# Altium schematic sheet Motorshield_ESP32_V4_schematic_outputjob.SchDoc (continued)

## Library data of the parts
A0-A3 = SOLDER_JUMPER_1206: Availability: Unavailable; Component Kind: Standard; MP: SOLDER_JUMPER_1206; Package: None; Price: None; Library Name: Motorshield_ESP32_V4_project_outputjob_1.SCHLIB
C1 = APD0609470M050R: Polymer Aluminium Capacitor Electrolyt; Manufacturer_JLCPCB: SHENGYANG; Manufacturer_Name: Kyocera AVX; Rotation Offset: 0; Component Kind: Standard; Datasheet Link: https://www.mouser.de/datasheet/2/40/kyocera_avx_apd_series-3177016.pdf; Footprint_JLCPCB: SMD,D6.3xL8mm; Height: 9.8mm; JLC PCB: C44606723; Manufacturer_Part_Number: APD0609470M050R; Library Name: Motorshield_ESP32_V4_project_outputjob_1.SCHLIB
C2 = APD0809101M050R: Polymer Aluminium Capacitor Electrolyt; Manufacturer_JLCPCB: Honor Elec; Manufacturer_Name: Kyocera AVX; Rotation Offset: 0; Component Kind: Standard; Datasheet Link: https://datasheets.kyocera-avx.com/kyocera-avx-apd-series.pdf; Footprint_JLCPCB: SMD,D8xL10.5mm; Height: 10mm; JLC PCB: C5246561; Manufacturer_Part_Number: APD0809101M050R; Mouser Part Number: 581-APD0809101M050R; Mouser Price/Stock: https://www.mouser.co.uk/ProductDetail/KYOCERA-AVX/APD0809101M050R?qs=Wj%2FVkw3K%252BMCgILDsoaMRTw%3D%3D; Library Name: Motorshield_ESP32_V4_project_outputjob_1.SCHLIB
C3 C5 C7 C8 C10 C11 C14 C16 C17 C19-C25 C32 C38 = 06035C104KAZ4A: Ceramic Capacitor MLCC; Manufacturer_JLCPCB: YAGEO; Manufacturer_Name: Kyocera AVX; Rotation Offset: 0; Arrow Part Number: 06035C104KAZ4A; Arrow Price/Stock: https://www.arrow.com/en/products/06035c104kaz4a/avx; Component Kind: Standard; Datasheet Link: https://componentsearchengine.com/Datasheets/1/06031A100FAT2A.pdf; Footprint_JLCPCB: 0603; Height: 0.9mm; JLC PCB: C14663; Manufacturer_Part_Number: 06035C104KAZ4A; Mouser Part Number: 581-06035C104KAZ4A; Mouser Price/Stock: https://www.mouser.co.uk/ProductDetail/AVX/06035C104KAZ4A/?qs=NI4NqA541gtiVdo7Hwl8%252BQ%3D%3D; Library Name: Motorshield_ESP32_V4_project_outputjob_1.SCHLIB
C4 C6 C12 C13 C37 = GRM21BZ71E106KE15L: Ceramitc Capacitor MLCC; Manufacturer_JLCPCB: Murata Electronics; Rotation Offset: 0; Arrow Part Number: GRM21BZ71E106KE15L; Arrow Price/Stock: https://www.arrow.com/en/products/grm21bz71e106ke15l/murata-manufacturing?utm_currency=USD&region=europe; Component Kind: Standard; Datasheet Link: https://search.murata.co.jp/Ceramy/image/img/A01X/G101/ENG/GRM21BZ71E106KE15-01A.pdf; Footprint_JLCPCB: 0805; Height: 1.45mm; JLC PCB: C440198; Manufacturer_Name: Murata Electronics; Manufacturer_Part_Number: GRM21BZ71E106KE15L; Mouser Part Number: 81-GRM21BZ71E106KE5L; Mouser Price/Stock: https://www.mouser.co.uk/ProductDetail/Murata-Electronics/GRM21BZ71E106KE15L?qs=Ey7%2FXF42M3fdXe9bIpCO9w%3D%3D; Library Name: Motorshield_ESP32_V4_project_outputjob_1.SCHLIB
C9 C15 C33-C36 = 06035C103K4T4A: Ceramic Capacitor MLCC; Manufacturer_JLCPCB: FH (Guangdong Fenghua Advanced Tech); Manufacturer_Name: Kyocera AVX; Rotation Offset: 0; Component Kind: Standard; Datasheet Link: https://componentsearchengine.com/Datasheets/1/06031A100FAT2A.pdf; Footprint_JLCPCB: 0603; Height: 0.9mm; JLC PCB: C57112; Manufacturer_Part_Number: 06035C103K4T4A; Mouser Part Number: 581-06035C103K4T4A; Mouser Price/Stock: https://www.mouser.com/Search/Refine.aspx?Keyword=581-06035C103K4T4A; Library Name: Motorshield_ESP32_V4_project_outputjob_1.SCHLIB
C18 = 06035C104KAZ4A: Ceramic Capacitor MLCC; Manufacturer_JLCPCB: YAGEO; Manufacturer_Name: Kyocera AVX; Arrow Part Number: 06035C104KAZ4A; Arrow Price/Stock: https://www.arrow.com/en/products/06035c104kaz4a/avx; Component Kind: Standard; Datasheet Link: https://componentsearchengine.com/Datasheets/1/06031A100FAT2A.pdf; Footprint_JLCPCB: 0603; Height: 0.9mm; JLC PCB: C14663; Manufacturer_Part_Number: 06035C104KAZ4A; Mouser Part Number: 581-06035C104KAZ4A; Mouser Price/Stock: https://www.mouser.co.uk/ProductDetail/AVX/06035C104KAZ4A/?qs=NI4NqA541gtiVdo7Hwl8%252BQ%3D%3D; Library Name: Motorshield_ESP32_V4_project_outputjob_1.SCHLIB
C26-C31 = EEH-ZC1H220P: Aluminium Capacitor Electrolyt; Manufacturer_JLCPCB: Honor Elec; Manufacturer_Name: Panasonic; Rotation Offset: +180; Component Kind: Standard; Datasheet Link: http://industrial.panasonic.com/cdbs/www-data/pdf/RDD0000/ABA0000C1234.pdf; Footprint_JLCPCB: SMD,D6.3xL5.8mm; Height: 8.3mm; JLC PCB: C5246560; Manufacturer_Part_Number: EEH-ZC1H220P; Mouser Part Number: 667-EEH-ZC1H220P; Mouser Price/Stock: https://www.mouser.co.uk/ProductDetail/Panasonic/EEH-ZC1H220P?qs=7UCyOFMWVHZ19OvZTDuZOA%3D%3D; Library Name: Motorshield_ESP32_V4_project_outputjob_1.SCHLIB
D1 = SBR3U40S1FQ-7: Diode, super barrier rectifiers; Manufacturer_JLCPCB: Diodes Incorporated; Rotation Offset: 0; Arrow Part Number: SBR3U40S1FQ-7; Arrow Price/Stock: https://www.arrow.com/en/products/sbr3u40s1fq-7/diodes-incorporated; Component Kind: Standard; Datasheet Link: https://www.diodes.com/assets/Datasheets/SBR3U40S1FQ.pdf; Footprint_JLCPCB: SOD-123F; Height: 1.15mm; JLC PCB: C4360673; Manufacturer_Name: Diodes Incorporated; Manufacturer_Part_Number: SBR3U40S1FQ-7; Mouser Part Number: 621-SBR3U40S1FQ-7; Mouser Price/Stock: https://www.mouser.co.uk/ProductDetail/Diodes-Incorporated/SBR3U40S1FQ-7?qs=1mbolxNpo8ch7miqGKbORA%3D%3D; Library Name: Motorshield_ESP32_V4_project_outputjob_1.SCHLIB
D2-D7 = CDSOD323-T15S: TVS Diode, unidirectional ESD/Surge Protection 15V; Manufacturer_JLCPCB: HXY MOSFET; Manufacturer_Name: Bourns; Rotation Offset: 0; Arrow Part Number: CDSOD323-T15S; Arrow Price/Stock: https://www.arrow.com/en/products/cdsod323-t15s/bourns?region=nac; Component Kind: Standard; Datasheet Link: https://www.bourns.com/docs/Product-Datasheets/CDSOD323-TxxSC.pdf; Footprint_JLCPCB: SOD-323; Height: 1.14mm; JLC PCB: C22439717; Manufacturer_Part_Number: CDSOD323-T15S; Mouser Part Number: 652-CDSOD323-T15S; Mouser Price/Stock: https://www.mouser.co.uk/ProductDetail/Bourns/CDSOD323-T15S?qs=6S5Watp5UnLXcGC4%252BIE2dA%3D%3D; Library Name: Motorshield_ESP32_V4_project_outputjob_1.SCHLIB
IC1 = PCA9617ADPJ: Integrated Circuit, level shifter I2C; Manufacturer_JLCPCB: NXP Semiconductor; Manufacturer_Name: NXP; Rotation Offset: +90; Arrow Part Number: PCA9617ADPJ; Arrow Price/Stock: https://www.arrow.com/en/products/pca9617adpj/nxp-semiconductors?utm_currency=USD&region=nac; Component Kind: Standard; Datasheet Link: http://www.nxp.com/docs/en/data-sheet/PCA9617A.pdf; Footprint_JLCPCB: TSSOP-8; Height: 1.1mm; JLC PCB: C128388; Manufacturer_Part_Number: PCA9617ADPJ; Mouser Part Number: 771-PCA9617ADPJ; Mouser Price/Stock: https://www.mouser.co.uk/ProductDetail/NXP-Semiconductors/PCA9617ADPJ?qs=cvU09TOkIMn2SIzokGN5qg%3D%3D; Library Name: Motorshield_ESP32_V4_project_outputjob_1.SCHLIB
IC2 IC6 = PCA9685PW,118: Integrated Circuit, LED Drivers/LED Drivers, I2C; Manufacturer_JLCPCB: NXP Semiconductor; Manufacturer_Name: NXP; Rotation Offset: 0; Arrow Part Number: PCA9685PW,118; Arrow Price/Stock: https://www.arrow.com/en/products/pca9685pw118/nxp-semiconductors?utm_currency=USD&region=nac; Component Kind: Standard; Datasheet Link: http://www.nxp.com/docs/en/data-sheet/PCA9685.pdf; Footprint_JLCPCB: TSSOP-28; Height: 1.1mm; JLC PCB: C2678753; Manufacturer_Part_Number: PCA9685PW,118; Mouser Part Number: 771-PCA9685PW,118; Mouser Price/Stock: https://www.mouser.co.uk/ProductDetail/NXP-Semiconductors/PCA9685PW118?qs=6YAT8rHPFU%252BtfwwKq4nwEQ%3D%3D; Library Name: Motorshield_ESP32_V4_project_outputjob_1.SCHLIB
IC3 IC4 = ADS1015IRUGR: Integrated Circuit, Data Acquisition/Analog to Digital Converters; Manufacturer_JLCPCB: Texas Instruments; Rotation Offset: 0; Arrow Part Number: ADS1015IRUGR; Arrow Price/Stock: https://www.arrow.com/en/products/ads1015irugr/texas-instruments?utm_currency=USD&region=nac; Component Kind: Standard; Datasheet Link: https://www.ti.com/lit/ds/symlink/ads1014.pdf?HQS=dis-mous-null-mousermode-dsf-pf-null-wwe&ts=1693594019978&ref_url=https%253A%252F%252Fwww.mouser.mx%252F; Footprint_JLCPCB: X2-QFN-10(1.5x2); Height: 0.4mm; JLC PCB: C468259; Manufacturer_Name: Texas Instruments; Manufacturer_Part_Number: ADS1015IRUGR; Mouser Part Number: 595-ADS1015IRUGR; Mouser Price/Stock: https://www.mouser.co.uk/ProductDetail/Texas-Instruments/ADS1015IRUGR?qs=IK5e5L0zOXiH4nzJ%2FqgaZA%3D%3D; Library Name: Motorshield_ESP32_V4_project_outputjob_1.SCHLIB
IC5 = MCP23017T-E_SS: Integrated Circuit, Interface I/O Expander 16 channel with interrupt; Manufacturer_JLCPCB: Microchip Tech; Manufacturer_Name: Microchip; Manufacturer_Part_Number: MCP23017T-E/SS; Rotation Offset: +90; Arrow Part Number: MCP23017T-E/SS; Arrow Price/Stock: https://www.arrow.com/en/products/mcp23017t-ess/microchip-technology?utm_currency=USD&region=nac; Component Kind: Standard; Datasheet Link: https://datasheet.datasheetarchive.com/originals/distributors/SFDatasheet-4/sf-00090057.pdf; Footprint_JLCPCB: SSOP-28; Height: 2mm; JLC PCB: C558584; Mouser Part Number: 579-MCP23017T-E/SS; Mouser Price/Stock: https://www.mouser.co.uk/ProductDetail/Microchip-Technology/MCP23017T-E-SS?qs=usxtMOJb1Rz2FhASIaFluQ%3D%3D; Library Name: Motorshield_ESP32_V4_project_outputjob_1.SCHLIB
J1 = Header links ESP32-S3: Connector, 22ckt, 1 row, pitch: 2.54mm, female; Manufacturer_JLCPCB: Megastar; Manufacturer_Name: SAMTEC; Manufacturer_Part_Number: CES-122-02-S-S; Rotation Offset: 0; Component Kind: Standard; Datasheet Link: https://suddendocs.samtec.com/prints/ces-1xx-xx-x-s-xx-mkt.pdf; Footprint_JLCPCB: Plugin, P = 2.54mm; Height: 5.207mm; JLC PCB: C7499337; Library Name: Motorshield_ESP32_V4_project_outputjob_1.SCHLIB
J2 = Header rechts ESP32-S3_1: Connector, 22ckt, 1 row, pitch: 2.54mm, female; Manufacturer_JLCPCB: Megastar; Manufacturer_Name: SAMTEC; Manufacturer_Part_Number: CES-122-02-S-S; Rotation Offset: 0; Component Kind: Standard; Datasheet Link: https://suddendocs.samtec.com/prints/ces-1xx-xx-x-s-xx-mkt.pdf; Footprint_JLCPCB: Plugin, P = 2.54mm; Height: 5.207mm; JLC PCB: C7499337; Library Name: Motorshield_ESP32_V4_project_outputjob_1.SCHLIB
J3 J4 J6-J8 = 90147-1108: Connector, 8ckt, 1 row, pitch: 2.54mm, female; Manufacturer_JLCPCB: BOOMELE(Boom Precision Elec); Manufacturer_Name: Molex; Rotation Offset: 0; Component Kind: Standard; Datasheet Link: https://www.molex.com/pdm_docs/sd/901471102_sd.pdf; Footprint_JLCPCB: Plugin, P = 2.54mm; Height: 8.7mm; JLC PCB: C27438; Manufacturer_Part_Number: 90147-1108; Mouser Part Number: 538-90147-1108; Mouser Price/Stock: https://www.mouser.co.uk/ProductDetail/Molex/90147-1108?qs=QESXi79X%252BKqiwXr7kUdEeg%3D%3D; Library Name: Motorshield_ESP32_V4_project_outputjob_1.SCHLIB
J5 = 691101710002: Connector, 2 ckt, screw terminal, picht: 5mm, 12A, blue; Manufacturer_JLCPCB: DORABO; Manufacturer_Name: Wurth Elektronik; Rotation Offset: +180; Component Kind: Standard; Datasheet Link: https://www.we-online.com/catalog/datasheet/691101710002.pdf; Footprint_JLCPCB: Plugin, P = 2.54mm; Height: 12.6mm; JLC PCB: C500019; Manufacturer_Part_Number: 691101710002; Mouser Part Number: 710-691101710002; Mouser Price/Stock: https://www.mouser.co.uk/ProductDetail/Wurth-Elektronik/691101710002?qs=7gQLVZk5cPko2q9q3mYFyQ%3D%3D; Library Name: Motorshield_ESP32_V4_project_outputjob_1.SCHLIB
J9 = 61300811121: Connector, 8ckt, 1 row, pitch: 2.54mm, male, yellow; Manufacturer_JLCPCB: XFCN; Manufacturer_Name: Wurth Elektronik; Rotation Offset: 0; Component Kind: Standard; Datasheet Link: https://www.we-online.com/components/products/download/3D_61300811121+%28rev1%29.pdf; Footprint_JLCPCB: Plugin, P = 2.54mm; Height: 8.54mm; JLC PCB: C41425311; Manufacturer_Part_Number: 61300811121; Mouser Part Number: 710-61300811121; Mouser Price/Stock: https://www.mouser.co.uk/ProductDetail/Wurth-Elektronik/61300811121?qs=PhR8RmCirEZRdx%252BVlEmRRA%3D%3D; Library Name: Motorshield_ESP32_V4_project_outputjob_1.SCHLIB
J10 = 61300811121: Connector, 8ckt, 1 row, pitch: 2.54mm, male, red; Manufacturer_JLCPCB: XFCN; Manufacturer_Name: Wurth Elektronik; Rotation Offset: 0; Component Kind: Standard; Datasheet Link: https://www.we-online.com/components/products/download/3D_61300811121+%28rev1%29.pdf; Footprint_JLCPCB: Plugin, P = 2.54mm; Height: 8.54mm; JLC PCB: C41425298; Manufacturer_Part_Number: 61300811121; Mouser Part Number: 710-61300811121; Mouser Price/Stock: https://www.mouser.co.uk/ProductDetail/Wurth-Elektronik/61300811121?qs=PhR8RmCirEZRdx%252BVlEmRRA%3D%3D; Library Name: Motorshield_ESP32_V4_project_outputjob_1.SCHLIB
J11 = 61300811121: Connector, 8ckt, 1 row, pitch: 2.54mm, male, black; Manufacturer_JLCPCB: XFCN; Manufacturer_Name: Wurth Elektronik; Rotation Offset: 0; Component Kind: Standard; Datasheet Link: https://www.we-online.com/components/products/download/3D_61300811121+%28rev1%29.pdf; Footprint_JLCPCB: Plugin, P = 2.54mm; Height: 8.54mm; JLC PCB: C492407; Manufacturer_Part_Number: 61300811121; Mouser Part Number: 710-61300811121; Mouser Price/Stock: https://www.mouser.co.uk/ProductDetail/Wurth-Elektronik/61300811121?qs=PhR8RmCirEZRdx%252BVlEmRRA%3D%3D; Library Name: Motorshield_ESP32_V4_project_outputjob_1.SCHLIB
J13 J15 J17 J18 = 5442170: Connector, 2 ckt, screw terminal, picht: 5mm, 12A, green; Manufacturer_JLCPCB: DORABO; Manufacturer_Name: Phoenix Contact; Rotation Offset: 0; Arrow Part Number: 5442170; Arrow Price/Stock: https://www.arrow.com/en/products/5442170/phoenix-contact; Component Kind: Standard; Datasheet Link: https://www.phoenixcontact.com/en-us/products/printed-circuit-board-terminal-bc-500x10-2-gn-5442170?type=pdf; Footprint_JLCPCB: Plugin, P = 5mm; Height: 10.12mm; JLC PCB: C395885; Manufacturer_Part_Number: 5442170; Mouser Part Number: 651-5442170; Mouser Price/Stock: https://www.mouser.co.uk/ProductDetail/Phoenix-Contact/5442170?qs=9Y9qB%2FCsC3l20JbvAQBiIQ%3D%3D; Library Name: Motorshield_ESP32_V4_project_outputjob_1.SCHLIB
J14 J16 = 5441537: Connector, 2 ckt, screw terminal, picht: 5mm, 12A, black; Manufacturer_JLCPCB: DORABO; Manufacturer_Name: Phoenix Contact; Rotation Offset: 0; Component Kind: Standard; Datasheet Link: https://www.phoenixcontact.com/en-us/products/printed-circuit-board-terminal-bc-500x10-2-bk-5441537?type=pdf; Footprint_JLCPCB: Plugin, P = 5mm; Height: 10.12mm; JLC PCB: C430623; Manufacturer_Part_Number: 5441537; Mouser Part Number: 651-5441537; Mouser Price/Stock: https://www.mouser.co.uk/ProductDetail/Phoenix-Contact/5441537?qs=iCzJi%2FIZBF68%252B9JNIUQa4w%3D%3D; Library Name: Motorshield_ESP32_V4_project_outputjob_1.SCHLIB
J19 = 90147-1107: Connector, 7 ckt, 1 row, pitch: 2.54mm, female; Manufacturer_JLCPCB: XFCN; Manufacturer_Name: Molex; Rotation Offset: 0; Component Kind: Standard; Datasheet Link: https://componentsearchengine.com/Datasheets/2/90147-1107.pdf; Footprint_JLCPCB: Plugin, P = 2.54mm; Height: 11.8mm; JLC PCB: C2832270; Manufacturer_Part_Number: 90147-1107; Mouser Part Number: 538-90147-1107; Mouser Price/Stock: https://www.mouser.co.uk/ProductDetail/Molex/90147-1107?qs=QESXi79X%252BKpbYN2OdNw17A%3D%3D; Library Name: Motorshield_ESP32_V4_project_outputjob_1.SCHLIB
J25 = SM04B-SRSS-TB_LF__SN_: Connector, QWIIC, wire to board; Manufacturer_JLCPCB: JST; Manufacturer_Name: JST (JAPAN SOLDERLESS TERMINALS); Manufacturer_Part_Number: SM04B-SRSS-TB(LF)(SN); Rotation Offset: 0; Arrow Part Number: SM04B-SRSS-TB(LF)(SN); Arrow Price/Stock: https://www.arrow.com/en/products/sm04b-srss-tb-lf-sn/jst-manufacturing.html?utm_currency=USD&region=europe; Component Kind: Standard; Datasheet Link: http://www.jst-mfg.com/product/pdf/eng/eSH.pdf; Footprint_JLCPCB: SMD,P=1mm,Surface Mount, right Angle; JLC PCB: C160404; Mouser Part Number: 306-SM04BSRSSTBLFSN; Mouser Price/Stock: https://www.mouser.co.uk/ProductDetail/JST-Commercial/SM04B-SRSS-TBLFSN?qs=cdbOS8ANM9B3FdyA6cNU2A%3D%3D; Library Name: Motorshield_ESP32_V4_project_outputjob_1.SCHLIB
Q1 = DMP3020LSS-13: P-MOSFET,  1 channel; Manufacturer_JLCPCB: Diodes Incorporated; Rotation Offset: +90; Component Kind: Standard; Datasheet Link: https://www.diodes.com//assets/Datasheets/ds31263.pdf; Footprint_JLCPCB: SO-8; Height: 1.75mm; JLC PCB: C155319; Manufacturer_Name: Diodes Incorporated; Manufacturer_Part_Number: DMP3020LSS-13; Mouser Part Number: 621-DMP3020LSS-13; Mouser Price/Stock: https://www.mouser.co.uk/ProductDetail/Diodes-Incorporated/DMP3020LSS-13?qs=ptj1V1atRApSH7nA47yoew%3D%3D; Library Name: Motorshield_ESP32_V4_project_outputjob_1.SCHLIB
R1 R3 R6 R14 R16 R17 R44-R47 = MCT0603MD1002DP500: Resistor  1%; Manufacturer_JLCPCB: YAGEO; Manufacturer_Name: Vishay; Rotation Offset: 0; Arrow Part Number: MCT0603MD1002DP500; Arrow Price/Stock: https://www.arrow.com/en/products/mct0603md1002dp500/vishay?region=nac; Component Kind: Standard; Datasheet Link: http://www.vishay.com/docs/28705/mcx0x0xpro.pdf; Footprint_JLCPCB: 0603; Height: 0.55mm; JLC PCB: C25804; Manufacturer_Part_Number: MCT0603MD1002DP500; Mouser Part Number: 594-MCT0603MD1002DP5; Mouser Price/Stock: https://www.mouser.co.uk/ProductDetail/Vishay-Beyschlag/MCT0603MD1002DP500?qs=oBjDkOCjPF1CUAoPD7yTwg%3D%3D; Library Name: Motorshield_ESP32_V4_project_outputjob_1.SCHLIB
R2 = RC0603FR-104K7L: Resistor  1%; Manufacturer_JLCPCB: UNI-ROYAL(Uniroyal Elec); Manufacturer_Name: YAGEO; Rotation Offset: 0; Arrow Part Number: RC0603FR-104K7L; Arrow Price/Stock: https://www.arrow.com/en/products/rc0603fr-104k7l/yageo; Component Kind: Standard; Datasheet Link: http://www.yageo.com/documents/recent/PYu-RC0603_51_RoHS_L_v5.pdf; Footprint_JLCPCB: 0603; Height: 0.55mm; JLC PCB: C23162; Manufacturer_Part_Number: RC0603FR-104K7L; Mouser Part Number: 603-RC0603FR-104K7L; Mouser Price/Stock: https://www.mouser.co.uk/ProductDetail/Yageo/RC0603FR-104K7L?qs=EiqXWrxQq63AD8HOZpfnFQ%3D%3D; Library Name: Motorshield_ESP32_V4_project_outputjob_1.SCHLIB
R4 = MCT06030C2701FP500: Resistor  1%; Manufacturer_JLCPCB: UNI-ROYAL(Uniroyal Elec); Manufacturer_Name: Vishay; Rotation Offset: 0; Component Kind: Standard; Datasheet Link: http://www.vishay.com/docs/28705/mcx0x0xpro.pdf; Footprint_JLCPCB: 0603; Height: 0.55mm; JLC PCB: C13167; Manufacturer_Part_Number: MCT06030C2701FP500; Mouser Part Number: 594-MCT06030C2701FP5; Mouser Price/Stock: https://www.mouser.co.uk/ProductDetail/Vishay-Beyschlag/MCT06030C2701FP500?qs=x3mz4GabKuo1b7QaS88WTg%3D%3D; Library Name: Motorshield_ESP32_V4_project_outputjob_1.SCHLIB
R5 = RC0603FR-136K8L: Resistor  1%; Manufacturer_JLCPCB: UNI-ROYAL(Uniroyal Elec); Manufacturer_Name: YAGEO; Rotation Offset: 0; Component Kind: Standard; Datasheet Link: http://www.yageo.com/documents/recent/PYu-RC0603_51_RoHS_L_v5.pdf; Footprint_JLCPCB: 0603; Height: 0.55mm; JLC PCB: C23212; Manufacturer_Part_Number: RC0603FR-136K8L; Mouser Part Number: 603-RC0603FR-136K8L; Mouser Price/Stock: https://www.mouser.com/Search/Refine.aspx?Keyword=603-RC0603FR-136K8L; Library Name: Motorshield_ESP32_V4_project_outputjob_1.SCHLIB
R7 R15 R48 R50 R51 = AC0603FR-1047KL: Resistor  1%; Manufacturer_JLCPCB: UNI-ROYAL(Uniroyal Elec); Manufacturer_Name: YAGEO; Rotation Offset: 0; Component Kind: Standard; Datasheet Link: https://www.yageo.com/upload/media/product/productsearch/datasheet/rchip/PYu-AC_51_RoHS_L_11.pdf; Footprint_JLCPCB: 0603; Height: 0.55mm; JLC PCB: C25819; Manufacturer_Part_Number: AC0603FR-1047KL; Library Name: Motorshield_ESP32_V4_project_outputjob_1.SCHLIB
R8 R10-R12 = RC0603FR-102K2L: Resistor  1%; Manufacturer_JLCPCB: UNI-ROYAL(Uniroyal Elec); Manufacturer_Name: YAGEO; Rotation Offset: 0; Component Kind: Standard; Datasheet Link: http://www.yageo.com/documents/recent/PYu-RC0603_51_RoHS_L_v5.pdf; Footprint_JLCPCB: 0603; Height: 0.55mm; JLC PCB: C4190; Manufacturer_Part_Number: RC0603FR-102K2L; Mouser Part Number: 603-RC0603FR-102K2L; Mouser Price/Stock: https://www.mouser.co.uk/ProductDetail/Yageo/RC0603FR-102K2L?qs=EiqXWrxQq60h%2FX40EXZ2%252Bg%3D%3D; Library Name: Motorshield_ESP32_V4_project_outputjob_1.SCHLIB
R9 R22 R25-R28 = AC0603FR-071K3L: Resistor  1%; Manufacturer_JLCPCB: UNI-ROYAL(Uniroyal Elec); Manufacturer_Name: Yageo Group; Rotation Offset: 0; Arrow Part Number: AC0603FR-071K3L; Arrow Price/Stock: https://www.arrow.com/en/products/ac0603fr-071k3l/yageo; Component Kind: Standard; Datasheet Link: https://www.mouser.com/datasheet/2/447/PYu-AC_51_RoHS_L_6-1152827.pdf; Footprint_JLCPCB: 0603; Height: 0.55mm; JLC PCB: C22767; Manufacturer_Part_Number: AC0603FR-071K3L; Mouser Part Number: 603-AC0603FR-071K3L; Mouser Price/Stock: https://www.mouser.com/Search/Refine.aspx?Keyword=603-AC0603FR-071K3L; Library Name: Motorshield_ESP32_V4_project_outputjob_1.SCHLIB
R13 R19-R21 R23 R24 R29-R42 = RK73H1JTTD22R0F: Resistor  1%; Manufacturer_JLCPCB: UNI-ROYAL(Uniroyal Elec); Manufacturer_Name: KOA Speer; Rotation Offset: 0; Arrow Part Number: RK73H1JTTD22R0F; Arrow Price/Stock: https://www.arrow.com/en/products/rk73h1jttd22r0f/koa-speer-electronics; Component Kind: Standard; Datasheet Link: http://www.koaspeer.com/catimages/Products/RK73-RT/RK73-RT.pdf; Footprint_JLCPCB: 0603; Height: 0.55mm; JLC PCB: C23345; Manufacturer_Part_Number: RK73H1JTTD22R0F; Mouser Part Number: 660-RK73H1JTTD22R0F; Mouser Price/Stock: https://www.mouser.co.uk/ProductDetail/KOA-Speer/RK73H1JTTD22R0F/?qs=jvHKaIPRtAQPZhBHYN4sRQ%3D%3D; Library Name: Motorshield_ESP32_V4_project_outputjob_1.SCHLIB
R49 = AC0603FR-1047KL: Resistor  1%; Manufacturer: UNI-ROYAL(Uniroyal Elec); Manufacturer_Name: YAGEO; Rotation Offset: 0; Component Kind: Standard; Datasheet Link: https://www.yageo.com/upload/media/product/productsearch/datasheet/rchip/PYu-AC_51_RoHS_L_11.pdf; Footprint_JLCPCB: 0603; Height: 0.55mm; JLC PCB: C25819; Manufacturer_Part_Number: AC0603FR-1047KL; Library Name: Motorshield_ESP32_V4_project_outputjob_1.SCHLIB
S1 = RA1H1C112R: Switch; Manufacturer_JLCPCB: E-Switch; Rotation Offset: 0; Component Kind: Standard; Datasheet Link: https://configured-product-images.s3.amazonaws.com/2D/specs/RA1H1C112R.pdf; Footprint_JLCPCB: Throughhole; Height: 15.2mm; JLC PCB: C3762839; Manufacturer_Name: E-Switch; Manufacturer_Part_Number: RA1H1C112R; Mouser Part Number: 612-RA1H1C112R; Mouser Price/Stock: https://www.mouser.co.uk/ProductDetail/E-Switch/RA1H1C112R?qs=Ep9Lwju7gVqk%252BSEJuJVdig%3D%3D; Library Name: Motorshield_ESP32_V4_project_outputjob_1.SCHLIB
TP1-TP51 = 837-22-001-30-001101: Testpoints; Manufacturer_JLCPCB: none; Manufacturer_Name: Mill-Max; Arrow Part Number: 837-22-001-30-001101; Arrow Price/Stock: https://www.arrow.com/en/products/837-22-001-30-001101/mill-max; Component Kind: Standard; Datasheet Link: https://www.digikey.com/en/products/detail/mill-max-manufacturing-corp/837-22-001-30-001101/7771369; Footprint_JLCPCB: Pad 1.5mm; Height: 9.63mm; Manufacturer_Part_Number: 837-22-001-30-001101; Mouser Part Number: 575-8372200130001101; Mouser Price/Stock: https://www.mouser.co.uk/ProductDetail/Mill-Max/837-22-001-30-001101?qs=BZBei1rCqCAeFxpZtFiTOQ%3D%3D; Library Name: Motorshield_ESP32_V4_project_outputjob_1.SCHLIB
U1 = TSR_3-2450N: Power Supply  DC DC CONVERTER 5V 15W; Manufacturer_JLCPCB: Traco Power; Manufacturer_Part_Number: TSR 3-2450N; Rotation Offset: 0; Component Kind: Standard; Datasheet Link: https://tracopower.com/tsr3n-datasheet/; Footprint_JLCPCB: Throughhole; Height: 10.7mm; JLC PCB: C42463890; Manufacturer_Name: Traco Power; Mouser Part Number: 495-TSR3-2450N; Mouser Price/Stock: https://www.mouser.co.uk/ProductDetail/TRACO-Power/TSR-3-2450N?qs=7%2F6SraaimPRZgUGX3XEenw%3D%3D; Library Name: Motorshield_ESP32_V4_project_outputjob_1.SCHLIB
U2 = TSR_1-2450E: Power Supply  DC DC CONVERTER 5V 5W; Manufacturer_JLCPCB: Traco Power; Manufacturer_Part_Number: TSR 1-2450E; Rotation Offset: 0; Arrow Part Number: TSR 1-2450E; Arrow Price/Stock: https://www.arrow.com/en/products/tsr-1-2450e/traco-electronic-ag?utm_currency=USD&region=europe; Component Kind: Standard; Datasheet Link: https://tracopower.com/tsr1e-datasheet/; Footprint_JLCPCB: SIP,11.6x7.5mm; Height: 10.6mm; JLC PCB: C5346721; Manufacturer_Name: Traco Power; Mouser Part Number: 495-TSR1-2450E; Mouser Price/Stock: https://www.mouser.co.uk/ProductDetail/TRACO-Power/TSR-1-2450E?qs=vmHwEFxEFR%2FlQnbAtEoeVA%3D%3D; Library Name: Motorshield_ESP32_V4_project_outputjob_1.SCHLIB
U3 U4 U6-U9 = DRV8251ADDAR: Motordriver H-Bridge; Manufacturer_JLCPCB: Texas Instruments; Rotation Offset: 0; Arrow Part Number: DRV8251ADDAR; Arrow Price/Stock: https://www.arrow.com/en/products/drv8251addar/texas-instruments?utm_currency=USD&region=nac; Component Kind: Standard; Datasheet Link: https://www.arrow.com/en/products/drv8251addar/texas-instruments?region=nac; Footprint_JLCPCB: SOPowerPAD-8; Height: 1.7mm; JLC PCB: C5218844; Manufacturer_Name: Texas Instruments; Manufacturer_Part_Number: DRV8251ADDAR; Mouser Part Number: 595-DRV8251ADDAR; Mouser Price/Stock: https://www.mouser.co.uk/ProductDetail/Texas-Instruments/DRV8251ADDAR?qs=MyNHzdoqoQKQYZTe%252B1jFCw%3D%3D; Library Name: Motorshield_ESP32_V4_project_outputjob_1.SCHLIB
